# Altium schematic sheet Intermediate_LCL_Top_20230320.SchDoc
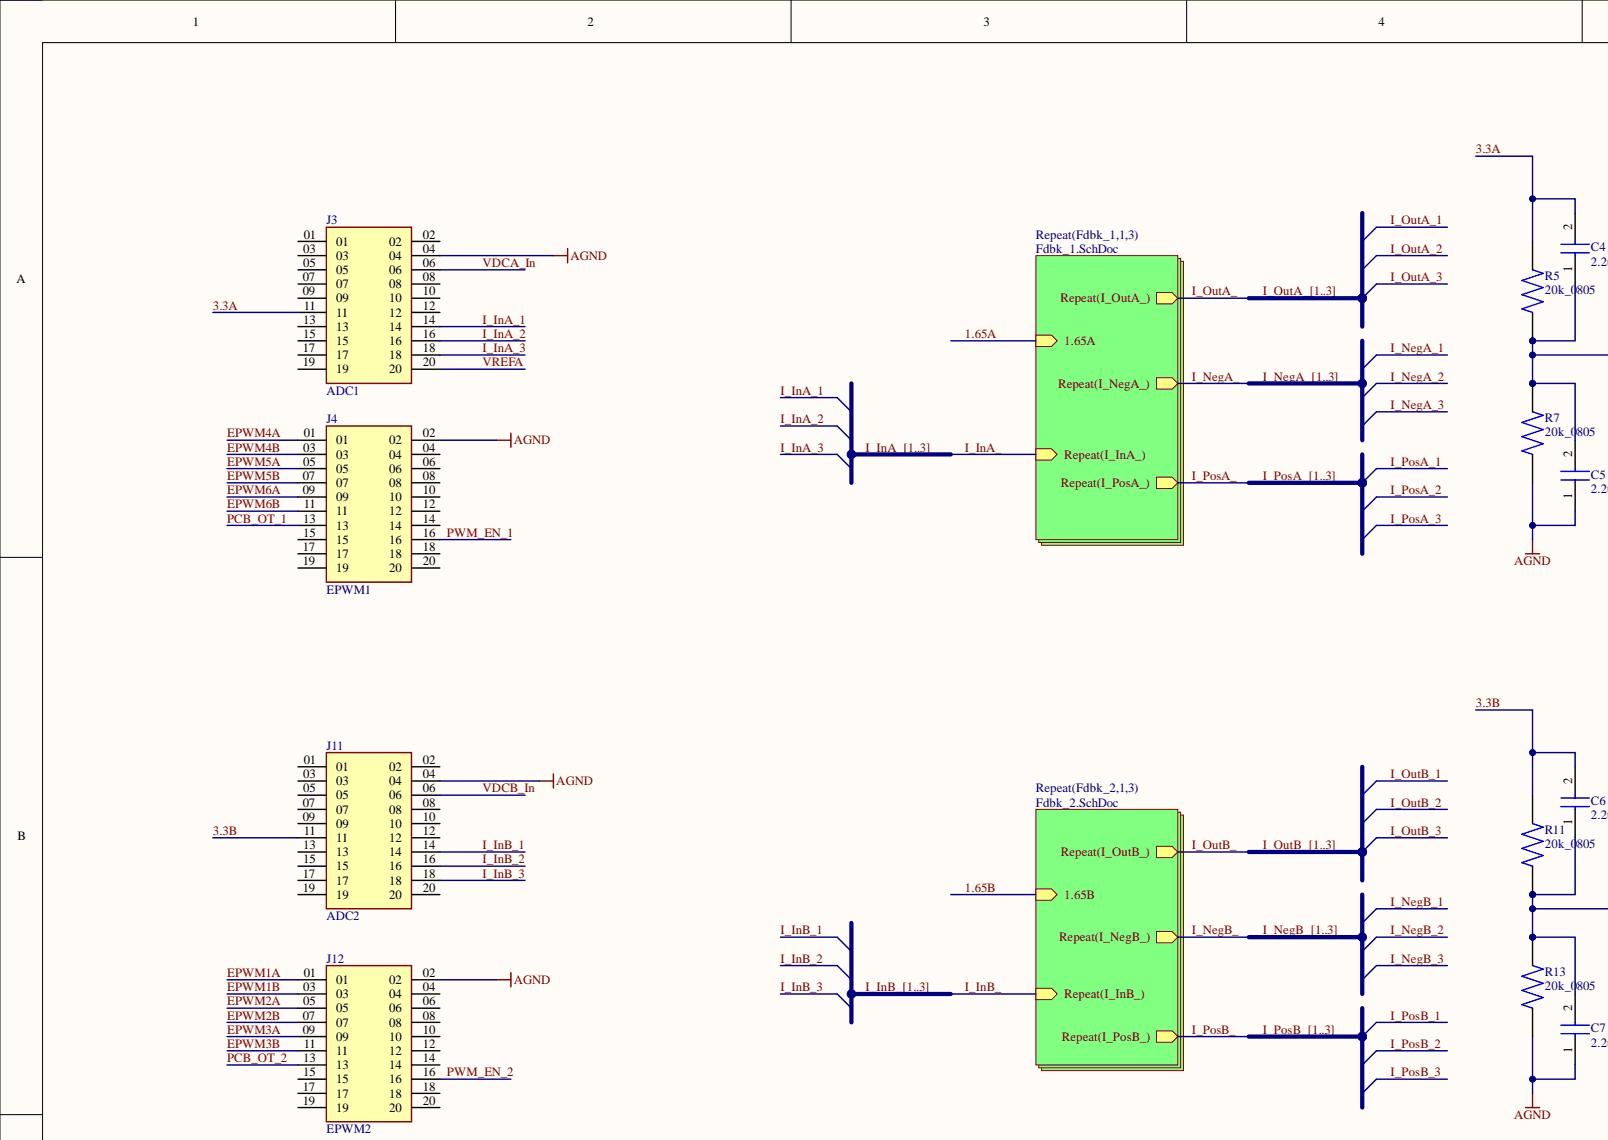
[diagram p0: Intermediate_LCL_Top_20230320.SchDoc, top left]
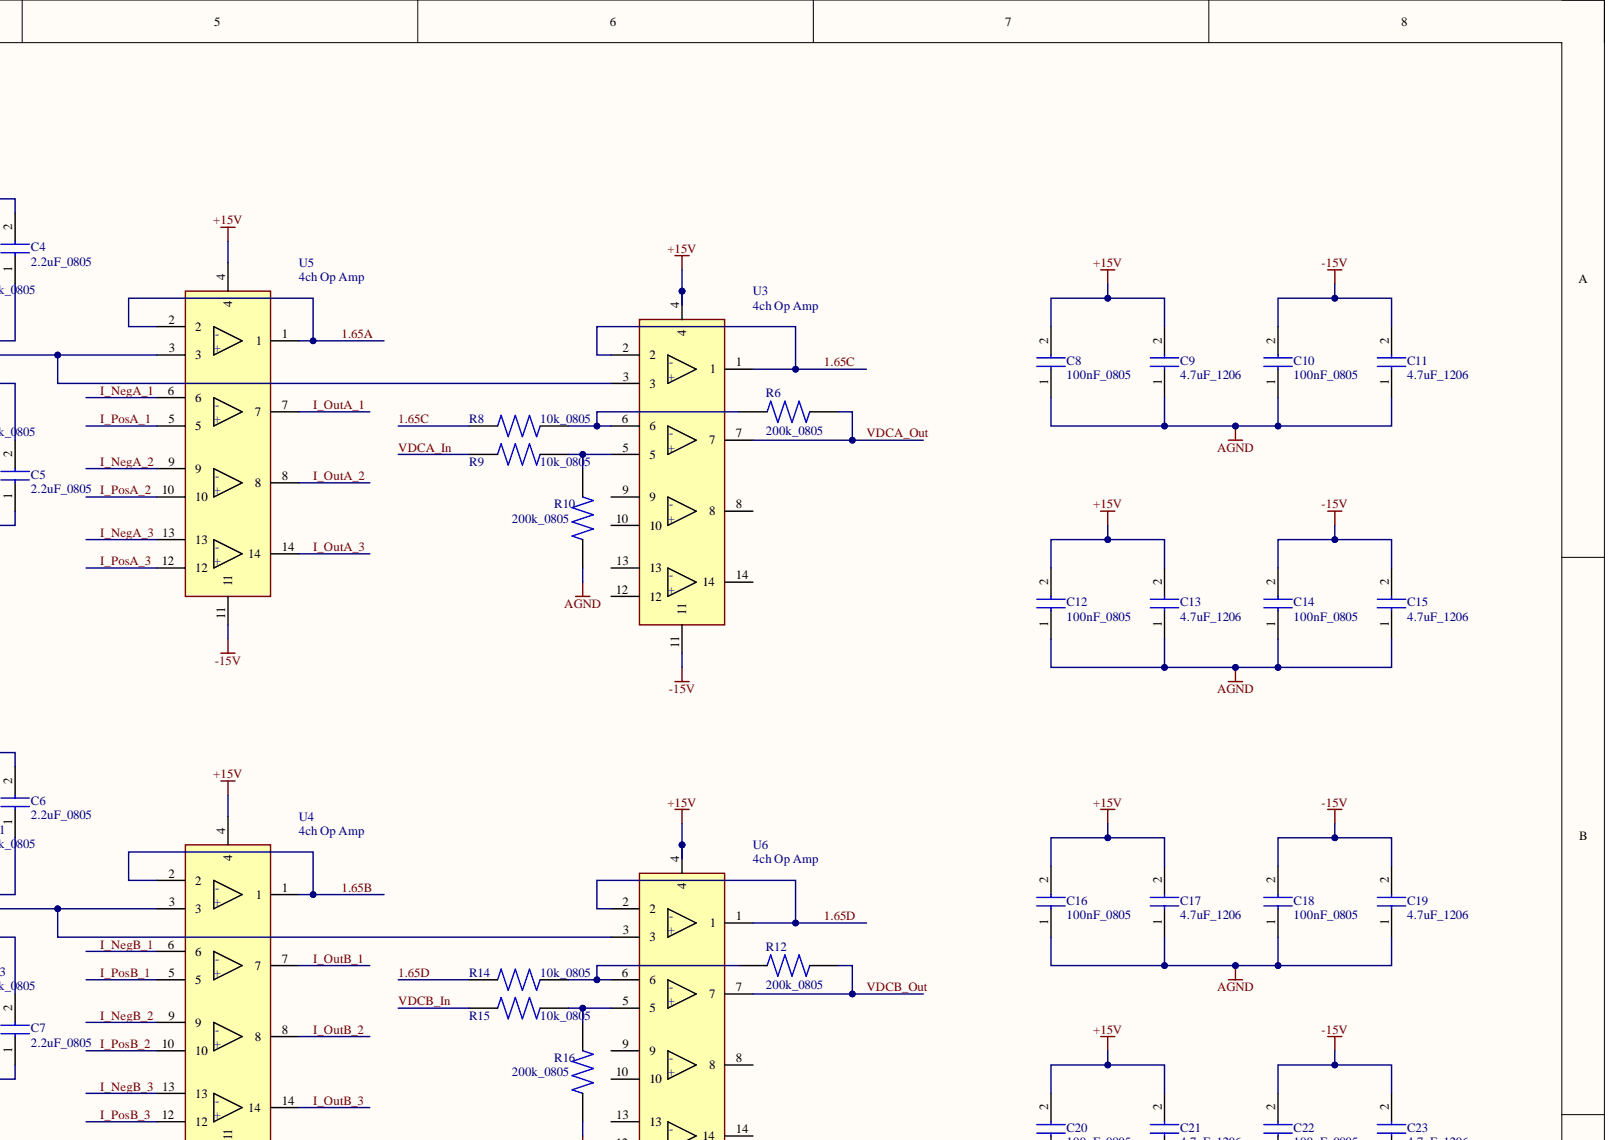
[diagram p1: Intermediate_LCL_Top_20230320.SchDoc, top right]
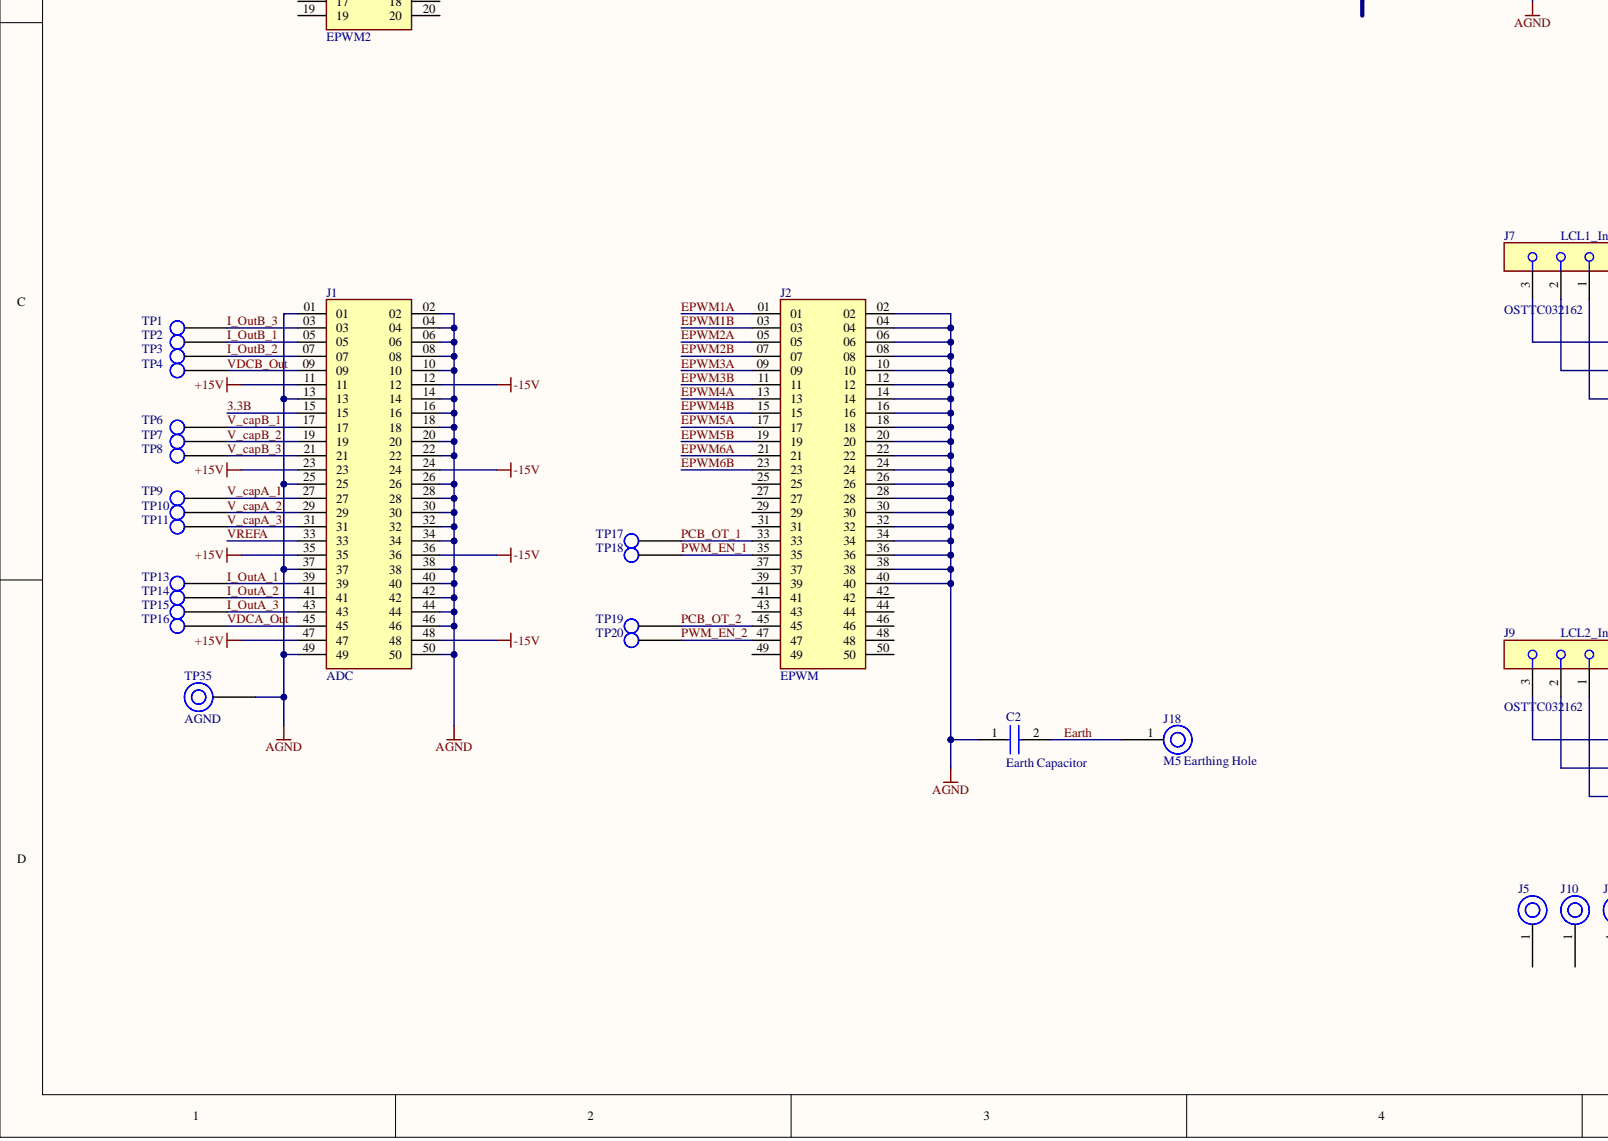
[diagram p2: Intermediate_LCL_Top_20230320.SchDoc, bottom left]
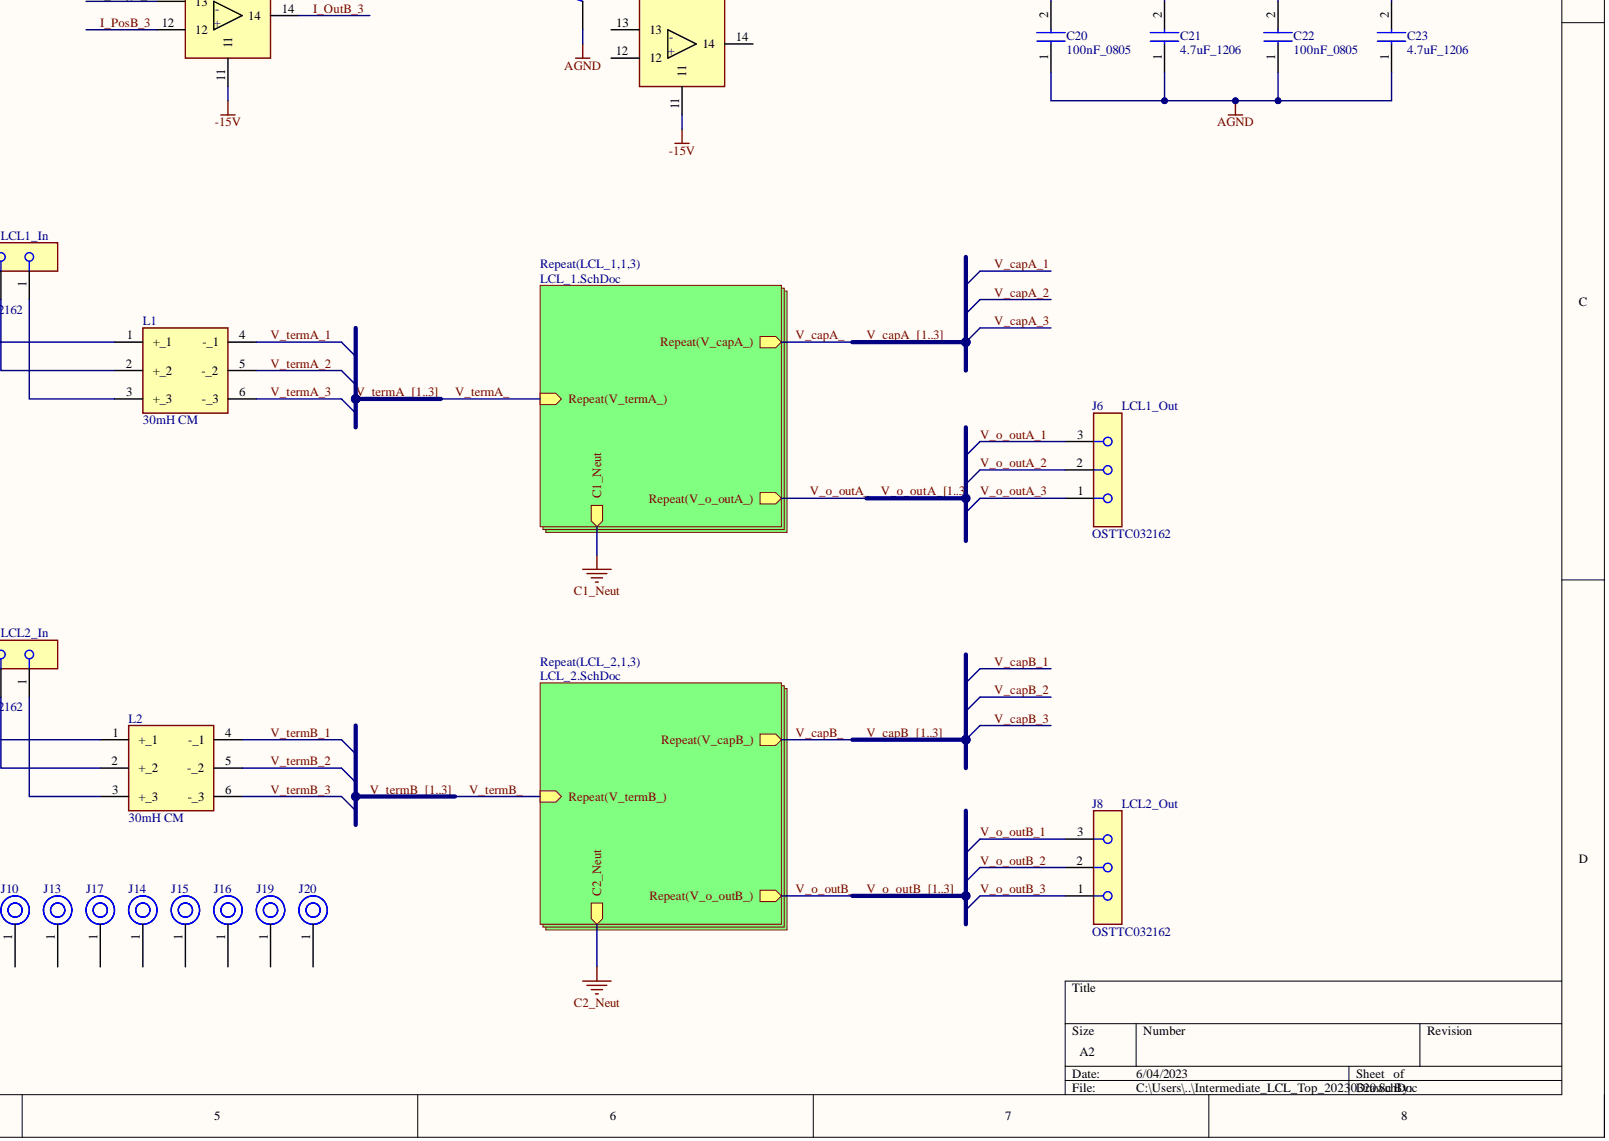
[diagram p3: Intermediate_LCL_Top_20230320.SchDoc, bottom right]

## Parts
C2  Earth Capacitor  [RAD-0.3]
C4  2.2uF_0805  [0805 Capacitor]
C5  2.2uF_0805  [0805 Capacitor]
C6  2.2uF_0805  [0805 Capacitor]
C7  2.2uF_0805  [0805 Capacitor]
C8  100nF_0805  [0805 Capacitor]
C9  4.7uF_1206  [1206 Capacitor]
C10  100nF_0805  [0805 Capacitor]
C11  4.7uF_1206  [1206 Capacitor]
C12  100nF_0805  [0805 Capacitor]
C13  4.7uF_1206  [1206 Capacitor]
C14  100nF_0805  [0805 Capacitor]
C15  4.7uF_1206  [1206 Capacitor]
C16  100nF_0805  [0805 Capacitor]
C17  4.7uF_1206  [1206 Capacitor]
C18  100nF_0805  [0805 Capacitor]
C19  4.7uF_1206  [1206 Capacitor]
C20  100nF_0805  [0805 Capacitor]
C21  4.7uF_1206  [1206 Capacitor]
C22  100nF_0805  [0805 Capacitor]
C23  4.7uF_1206  [1206 Capacitor]
J1  ADC  [SHF-125-01-L-D-RA]
J2  EPWM  [SHF-125-01-L-D-RA]
J3  ADC1  [SSQ-110-03-T-D]
J4  EPWM1  [SSQ-110-03-T-D]
J5  M5 Mounting Hole  [M5 Mounting Hole]  (value not drawn)
J6  LCL1_Out  Part Number: OSTTC032162  [3 Pin Terminal]
J7  LCL1_In  Part Number: OSTTC032162  [3 Pin Terminal]
J8  LCL2_Out  Part Number: OSTTC032162  [3 Pin Terminal]
J9  LCL2_In  Part Number: OSTTC032162  [3 Pin Terminal]
J10  M5 Mounting Hole  [M5 Mounting Hole]  (value not drawn)
J11  ADC2  [SSQ-110-03-T-D]
J12  EPWM2  [SSQ-110-03-T-D]
J13  M5 Mounting Hole  [M5 Mounting Hole]  (value not drawn)
J14  M5 Mounting Hole  [M5 Mounting Hole]  (value not drawn)
J15  M5 Mounting Hole  [M5 Mounting Hole]  (value not drawn)
J16  M5 Mounting Hole  [M5 Mounting Hole]  (value not drawn)
J17  M5 Mounting Hole  [M5 Mounting Hole]  (value not drawn)
J18  M5 Earthing Hole  [M5 Earthing Hole]
J19  M5 Mounting Hole  [M5 Mounting Hole]  (value not drawn)
J20  M5 Mounting Hole  [M5 Mounting Hole]  (value not drawn)
L1  30mH CM  [CM Choke Custom]
L2  30mH CM  [CM Choke Custom]
R5  20k_0805  [0805 Resistor]
R6  200k_0805  [0805 Resistor]
R7  20k_0805  [0805 Resistor]
R8  10k_0805  [0805 Resistor]
R9  10k_0805  [0805 Resistor]
R10  200k_0805  [0805 Resistor]
R11  20k_0805  [0805 Resistor]
R12  200k_0805  [0805 Resistor]
R13  20k_0805  [0805 Resistor]
R14  10k_0805  [0805 Resistor]
R15  10k_0805  [0805 Resistor]
R16  200k_0805  [0805 Resistor]
TP1  I3  [PCB 1.32mm TestPoint]  (value not drawn)
TP2  I1  [PCB 1.32mm TestPoint]  (value not drawn)
TP3  I2  [PCB 1.32mm TestPoint]  (value not drawn)
TP4  VDC  [PCB 1.32mm TestPoint]
TP6  VC_2A  [PCB 1.32mm TestPoint]  (value not drawn)
TP7  VC_2B  [PCB 1.32mm TestPoint]  (value not drawn)
TP8  VC_2C  [PCB 1.32mm TestPoint]  (value not drawn)
TP9  VC_1A  [PCB 1.32mm TestPoint]  (value not drawn)
TP10  VC_1B  [PCB 1.32mm TestPoint]  (value not drawn)
TP11  VC_1C  [PCB 1.32mm TestPoint]  (value not drawn)
TP13  I1  [PCB 1.32mm TestPoint]  (value not drawn)
TP14  I2  [PCB 1.32mm TestPoint]  (value not drawn)
TP15  I3  [PCB 1.32mm TestPoint]  (value not drawn)
TP16  VDC  [PCB 1.32mm TestPoint]
TP17  OT1  [PCB 1.32mm TestPoint]  (value not drawn)
TP18  PWMEN1  [PCB 1.32mm TestPoint]
TP19  OT2  [PCB 1.32mm TestPoint]  (value not drawn)
TP20  PWMEN2  [PCB 1.32mm TestPoint]
TP35  AGND  [1.9mm Hole]
U3  4ch Op Amp  [SOIC-14]
U4  4ch Op Amp  [SOIC-14]
U5  4ch Op Amp  [SOIC-14]
U6  4ch Op Amp  [SOIC-14]

## Nets
+15V: C8.2 C9.2 C12.2 C13.2 C16.2 C17.2 C20.2 C21.2 J1.11 J1.23 J1.35 J1.47 U3.4 U4.4 U5.4 U6.4
-15V: C10.2 C11.2 C14.2 C15.2 C18.2 C19.2 C22.2 C23.2 J1.12 J1.24 J1.36 J1.48 U3.11 U4.11 U5.11 U6.11
AGND: C2.1 C5.1 C7.1 C8.1 C9.1 C10.1 C11.1 C12.1 C13.1 C14.1 C15.1 C16.1 C17.1 C18.1 C19.1 C20.1 C21.1 C22.1 C23.1 J1.01 J1.02 J1.04 J1.06 J1.08 J1.10 J1.13 J1.14 J1.16 J1.18 J1.20 J1.22 J1.25 J1.26 J1.28 J1.30 J1.32 J1.34 J1.37 J1.38 J1.40 J1.42 J1.44 J1.46 J1.49 J1.50 J2.02 J2.04 J2.06 J2.08 J2.10 J2.12 J2.14 J2.16 J2.18 J2.20 J2.22 J2.24 J2.26 J2.28 J2.30 J2.32 J2.34 J2.36 J2.38 J2.40 J3.04 J4.02 J11.04 J12.02 R7.1 R10.2 R13.1 R16.2 TP35.1
1.65A: U5.1 U5.2
1.65B: U4.1 U4.2
1.65C: R8.1 U3.1 U3.2
1.65D: R14.1 U6.1 U6.2
3.3A: C4.2 J3.11 R5.2
3.3B: C6.2 J1.15 J11.11 R11.2
Earth: C2.2 J18.1
EPWM1A: J2.01 J12.01
EPWM1B: J2.03 J12.03
EPWM2A: J2.05 J12.05
EPWM2B: J2.07 J12.07
EPWM3A: J2.09 J12.09
EPWM3B: J2.11 J12.11
EPWM4A: J2.13 J4.01
EPWM4B: J2.15 J4.03
EPWM5A: J2.17 J4.05
EPWM5B: J2.19 J4.07
EPWM6A: J2.21 J4.09
EPWM6B: J2.23 J4.11
I_InA_1: J3.14  [also labelled I_InA_]
I_InA_2: J3.16  [also labelled I_InA_]
I_InA_3: J3.18  [also labelled I_InA_]
I_InB_1: J11.14  [also labelled I_InB_]
I_InB_2: J11.16  [also labelled I_InB_]
I_InB_3: J11.18  [also labelled I_InB_]
I_NegA_1: U5.6  [also labelled I_NegA_]
I_NegA_2: U5.9  [also labelled I_NegA_]
I_NegA_3: U5.13  [also labelled I_NegA_]
I_NegB_1: U4.6  [also labelled I_NegB_]
I_NegB_2: U4.9  [also labelled I_NegB_]
I_NegB_3: U4.13  [also labelled I_NegB_]
I_OutA_1: J1.39 TP13.1 U5.7  [also labelled I_OutA_]
I_OutA_2: J1.41 TP14.1 U5.8  [also labelled I_OutA_]
I_OutA_3: J1.43 TP15.1 U5.14  [also labelled I_OutA_]
I_OutB_1: J1.05 TP2.1 U4.7  [also labelled I_OutB_]
I_OutB_2: J1.07 TP3.1 U4.8  [also labelled I_OutB_]
I_OutB_3: J1.03 TP1.1 U4.14  [also labelled I_OutB_]
I_PosA_1: U5.5  [also labelled I_PosA_]
I_PosA_2: U5.10  [also labelled I_PosA_]
I_PosA_3: U5.12  [also labelled I_PosA_]
I_PosB_1: U4.5  [also labelled I_PosB_]
I_PosB_2: U4.10  [also labelled I_PosB_]
I_PosB_3: U4.12  [also labelled I_PosB_]
PCB_OT_1: J2.33 J4.13 TP17.1
PCB_OT_2: J2.45 J12.13 TP19.1
PWM_EN_1: J2.35 J4.16 TP18.1
PWM_EN_2: J2.47 J12.16 TP20.1
V_capA_1: J1.27 TP9.1  [also labelled V_capA_]
V_capA_2: J1.29 TP10.1  [also labelled V_capA_]
V_capA_3: J1.31 TP11.1  [also labelled V_capA_]
V_capB_1: J1.17 TP6.1  [also labelled V_capB_]
V_capB_2: J1.19 TP7.1  [also labelled V_capB_]
V_capB_3: J1.21 TP8.1  [also labelled V_capB_]
V_o_outA_1: J6.3  [also labelled V_o_outA_]
V_o_outA_2: J6.2  [also labelled V_o_outA_]
V_o_outA_3: J6.1  [also labelled V_o_outA_]
V_o_outB_1: J8.3  [also labelled V_o_outB_]
V_o_outB_2: J8.2  [also labelled V_o_outB_]
V_o_outB_3: J8.1  [also labelled V_o_outB_]
V_termA_1: L1.4(-_1)  [also labelled V_termA_]
V_termA_2: L1.5(-_2)  [also labelled V_termA_]
V_termA_3: L1.6(-_3)  [also labelled V_termA_]
V_termB_1: L2.4(-_1)  [also labelled V_termB_]
V_termB_2: L2.5(-_2)  [also labelled V_termB_]
V_termB_3: L2.6(-_3)  [also labelled V_termB_]
VDCA_In: J3.06 R9.1
VDCA_Out: J1.45 R6.1 TP16.1 U3.7
VDCB_In: J11.06 R15.1
VDCB_Out: J1.09 R12.1 TP4.1 U6.7
VREFA: J1.33 J3.20
NetC4_1: C4.1 C5.2 R5.1 R7.2 U3.3 U5.3
NetC6_1: C6.1 C7.2 R11.1 R13.2 U4.3 U6.3
NetJ2_25: J2.25
NetJ2_27: J2.27
NetJ2_29: J2.29
NetJ2_31: J2.31
NetJ2_37: J2.37
NetJ2_39: J2.39
NetJ2_41: J2.41
NetJ2_42: J2.42
NetJ2_43: J2.43
NetJ2_44: J2.44
NetJ2_46: J2.46
NetJ2_48: J2.48
NetJ2_49: J2.49
NetJ2_50: J2.50
NetJ3_01: J3.01
NetJ3_02: J3.02
NetJ3_03: J3.03
NetJ3_05: J3.05
NetJ3_07: J3.07
NetJ3_08: J3.08
NetJ3_09: J3.09
NetJ3_10: J3.10
NetJ3_12: J3.12
NetJ3_13: J3.13
NetJ3_15: J3.15
NetJ3_17: J3.17
NetJ3_19: J3.19
NetJ4_04: J4.04
NetJ4_06: J4.06
NetJ4_08: J4.08
NetJ4_10: J4.10
NetJ4_12: J4.12
NetJ4_14: J4.14
NetJ4_15: J4.15
NetJ4_17: J4.17
NetJ4_18: J4.18
NetJ4_19: J4.19
NetJ4_20: J4.20
NetJ5_1: J5.1
NetJ7_1: J7.1 L1.3(+_3)
NetJ7_2: J7.2 L1.2(+_2)
NetJ7_3: J7.3 L1.1(+_1)
NetJ9_1: J9.1 L2.3(+_3)
NetJ9_2: J9.2 L2.2(+_2)
NetJ9_3: J9.3 L2.1(+_1)
NetJ10_1: J10.1
NetJ11_01: J11.01
NetJ11_02: J11.02
NetJ11_03: J11.03
NetJ11_05: J11.05
NetJ11_07: J11.07
NetJ11_08: J11.08
NetJ11_09: J11.09
NetJ11_10: J11.10
NetJ11_12: J11.12
NetJ11_13: J11.13
NetJ11_15: J11.15
NetJ11_17: J11.17
NetJ11_19: J11.19
NetJ11_20: J11.20
NetJ12_04: J12.04
NetJ12_06: J12.06
NetJ12_08: J12.08
NetJ12_10: J12.10
NetJ12_12: J12.12
NetJ12_14: J12.14
NetJ12_15: J12.15
NetJ12_17: J12.17
NetJ12_18: J12.18
NetJ12_19: J12.19
NetJ12_20: J12.20
NetJ13_1: J13.1
NetJ14_1: J14.1
NetJ15_1: J15.1
NetJ16_1: J16.1
NetJ17_1: J17.1
NetJ19_1: J19.1
NetJ20_1: J20.1
NetR6_2: R6.2 R8.2 U3.6
NetR9_2: R9.2 R10.1 U3.5
NetR12_2: R12.2 R14.2 U6.6
NetR15_2: R15.2 R16.1 U6.5
NetU3_8: U3.8
NetU3_9: U3.9
NetU3_10: U3.10
NetU3_12: U3.12
NetU3_13: U3.13
NetU3_14: U3.14
NetU6_8: U6.8
NetU6_9: U6.9
NetU6_10: U6.10
NetU6_12: U6.12
NetU6_13: U6.13
NetU6_14: U6.14
C1_Neut:
C2_Neut:

## Library data of the parts
C2 = 102_Y: Y Earth Capacitor; MP: C1206C120J5GACTU; Availability: Unavailable; Component Kind: Standard; Package: None; Price: None; Library Name: FYP_Components.SchLib
C4-C7 = C2012X5R1H225K125AB: SMD Multilayer Ceramic Capacitor, 2.2 µF, 50 V, 0805 [2012 Metric], ± 10%, X5R, C; Component Kind: Standard; Library Name: FYP_Components.SchLib
C8 C10 C12 C14 C16 C18 C20 C22 = C0805C104J5RACTU: SMD Multilayer Ceramic Capacitor, 0.1 µF, 50 V, 0805 [2012 Metric], ± 5%, X7R, C Series KEMET; Component Kind: Standard; Library Name: FYP_Components.SchLib
C9 C11 C13 C15 C17 C19 C21 C23 = C3216X7R1H475K160AC: SMD Multilayer Ceramic Capacitor, 4.7 µF, 50 V, 1206 [3216 Metric], ± 10%, X7R, C; MP: C1206C120J5GACTU; Availability: Unavailable; Component Kind: Standard; Package: None; Price: None; Library Name: FYP_Components.SchLib
J1 J2 = SHF-125-01-L-D-RA: 50-Pin Simplus Connector; MF: Samtec; Availability: Unavailable; Component Kind: Standard; MP: SHF-125-01-L-D-RA; Package: None; Price: None; Library Name: FYP_Components.SchLib
J3 J4 J11 J12 = SSQ-110-03-T-D: SSQ - Samtec 2.54mm Square Tail Dual Row Socket Strip 8.5mm Profile - Fast Stock; MF: Samtec Inc.; Availability: In Stock; Check_prices: https://www.snapeda.com/parts/SSQ-110-03-T-D/Samtec%20Inc./view-part/616109/?ref=eda; Component Kind: Standard; MP: SSQ-110-03-T-D; Package: None; Price: None; Purchase-URL: https://pricing.snapeda.com/search/part/SSQ-110-03-T-D/?ref=eda; SnapEDA_Link: https://www.snapeda.com/parts/SSQ-110-03-T-D/Samtec%20Inc./view-part/616109/?ref=snap; Library Name: FYP_Components.SchLib
J6-J9 = 3PinTerminal: 3 Position Terminal Block 5.08mm; Manufacturer: On Shore Technology; Is Female: yes; Voltage: 300V; Component Kind: Standard; ComponentLink1Description: Upverter; ComponentLink1URL: https://upverter.com/upn/revision/2b80058b23306b34/; ComponentLink2Description: Datasheet; ComponentLink2URL: https://upverter.com/datasheet/d9a5d2e095ec14efdc364e45047c257fc4ca76eb.pdf; Digikey Description: CONN TERM BLOCK 5.08MM VERT 3POS PCB; Digikey Part Number: ED2610-ND; helpURL: https://upverter.com/upn/revision/2b80058b23306b34/; Lead Free: yes; Package: HDR03; Price: 0.382016875697; RoHS: TRUE; Supplier 1: Digi-Key; Supplier 2: Mouser; Supplier Part Number 1: ED2610-ND; Temperature: -30°C to +105°C; Temperature Range High: +105°C; Temperature Range Low: -30°C; Library Name: FYP_Components.SchLib
L1 L2 = 30mH Custom CM Choke: Manufacturer_Name: Wurth Elektronik; Manufacturer_Part_Number: 744839029220; Component Kind: Standard; Datasheet Link: https://componentsearchengine.com//744839029220.pdf; Description: 30mH Custom CM Choke; Height: 39mm; Mouser Part Number: 710-744839029220; Mouser Price/Stock: https://www.mouser.co.uk/ProductDetail/Wurth-Elektronik/744839029220?qs=MoCBKJu1Jj15mDhjF%2F4U%252Bg%3D%3D; Library Name: FYP_Components.SchLib
R5 R7 R11 R13 = CRCW080520K0FKEA: SMD Chip Resistor, 20 kohm, ± 1%, 125 mW, 0805 [2012 Metric], Thick Film, General Purpose; MF: Bourns; MP: CRE2512-FZ-R007E-3; Availability: Good; Component Kind: Standard; DigiKey-Purchase-URL: https://snapeda.com/shop?store=DigiKey&id=836123; Mouser-Purchase-URL: https://snapeda.com/shop?store=Mouser&id=836123; Package: 6432 Stackpole; Price: None; SnapEDA_Link: https://www.snapeda.com/parts/CRE2512-FZ-R007E-3/Bourns/view-part/836123/?ref=snap; Library Name: FYP_Components.SchLib
R6 R10 R12 R16 = CRCW0805200KFKEA: SMD Chip Resistor, 200 kohm, ± 1%, 125 mW, 0805 [2012 Metric], Thick Film, General Purpose; MF: Bourns; MP: CRE2512-FZ-R007E-3; Availability: Good; Component Kind: Standard; DigiKey-Purchase-URL: https://snapeda.com/shop?store=DigiKey&id=836123; Mouser-Purchase-URL: https://snapeda.com/shop?store=Mouser&id=836123; Package: 6432 Stackpole; Price: None; SnapEDA_Link: https://www.snapeda.com/parts/CRE2512-FZ-R007E-3/Bourns/view-part/836123/?ref=snap; Library Name: FYP_Components.SchLib
R8 R9 R14 R15 = CRCW080510K0FKEA: SMD Chip Resistor, 10 kohm, ± 1%, 125 mW, 0805 [2012 Metric], Thick Film, General Purpose; MF: Bourns; MP: CRE2512-FZ-R007E-3; Availability: Good; Component Kind: Standard; DigiKey-Purchase-URL: https://snapeda.com/shop?store=DigiKey&id=836123; Mouser-Purchase-URL: https://snapeda.com/shop?store=Mouser&id=836123; Package: 6432 Stackpole; Price: None; SnapEDA_Link: https://www.snapeda.com/parts/CRE2512-FZ-R007E-3/Bourns/view-part/836123/?ref=snap; Library Name: FYP_Components.SchLib
TP1-TP4 TP6-TP11 TP13-TP20 = PCBTestpoint: 20-313143 PCB Test Point, White, Through Hole Mount, 1.32 mm, Phosphor Bronze, Tin Plated Contacts; Component Kind: Standard; Library Name: FYP_Components.SchLib
TP35 = GroundTestPoint: H2182-01 PCB Test Point, PCB Mount, 1.9 mm, Brass, Tin Plated Contacts; Component Kind: Standard; Library Name: FYP_Components.SchLib
U3-U6 = TL074D: Quad, 30-V, 3-MHz, high slew rate (13-V/µs), In to V+, JFET-input op amp; Component Kind: Standard; Library Name: FYP_Components.SchLib
J5 J10 J13-J17 J19 J20 = M5 Mounting Hole: Component Kind: Standard; Library Name: FYP_Components.SchLib
J18 = M5 Earthing Hole: Component Kind: Standard; Library Name: FYP_Components.SchLib
